# Altium schematic sheet lv_power_5V_cv.SchDoc(lv_power_5V_cv)
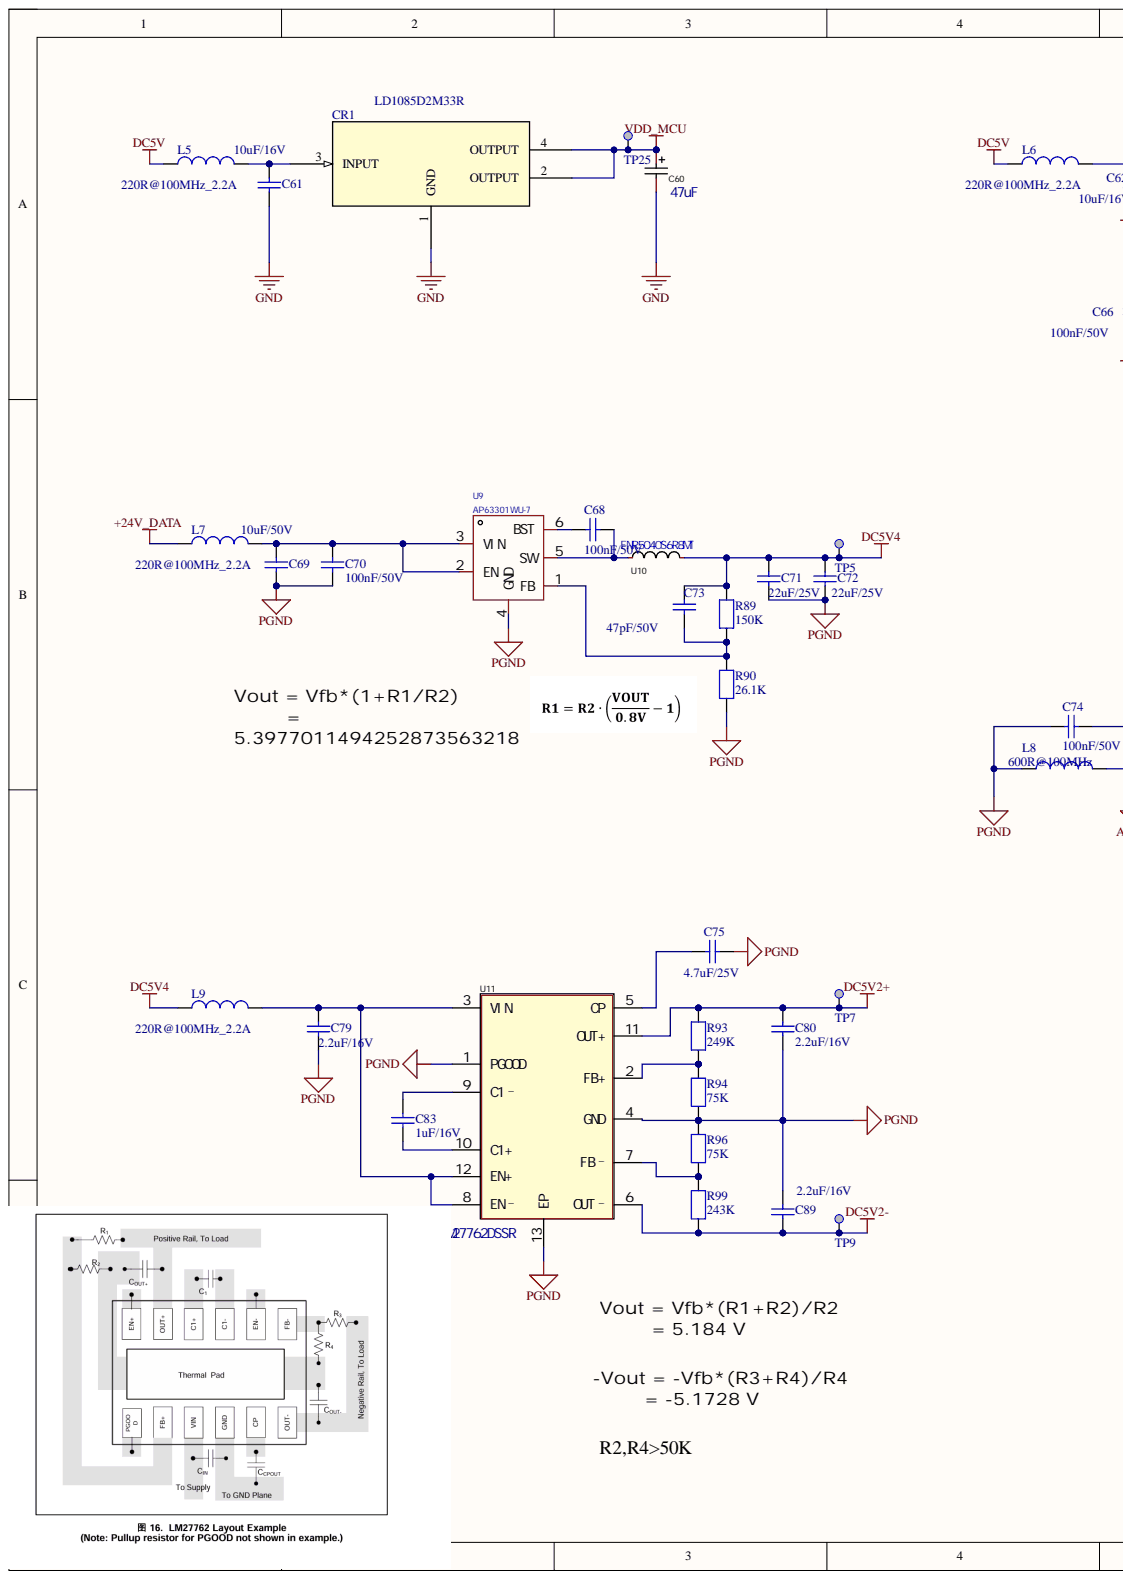
[diagram p0: lv_power_5V_cv.SchDoc, left]
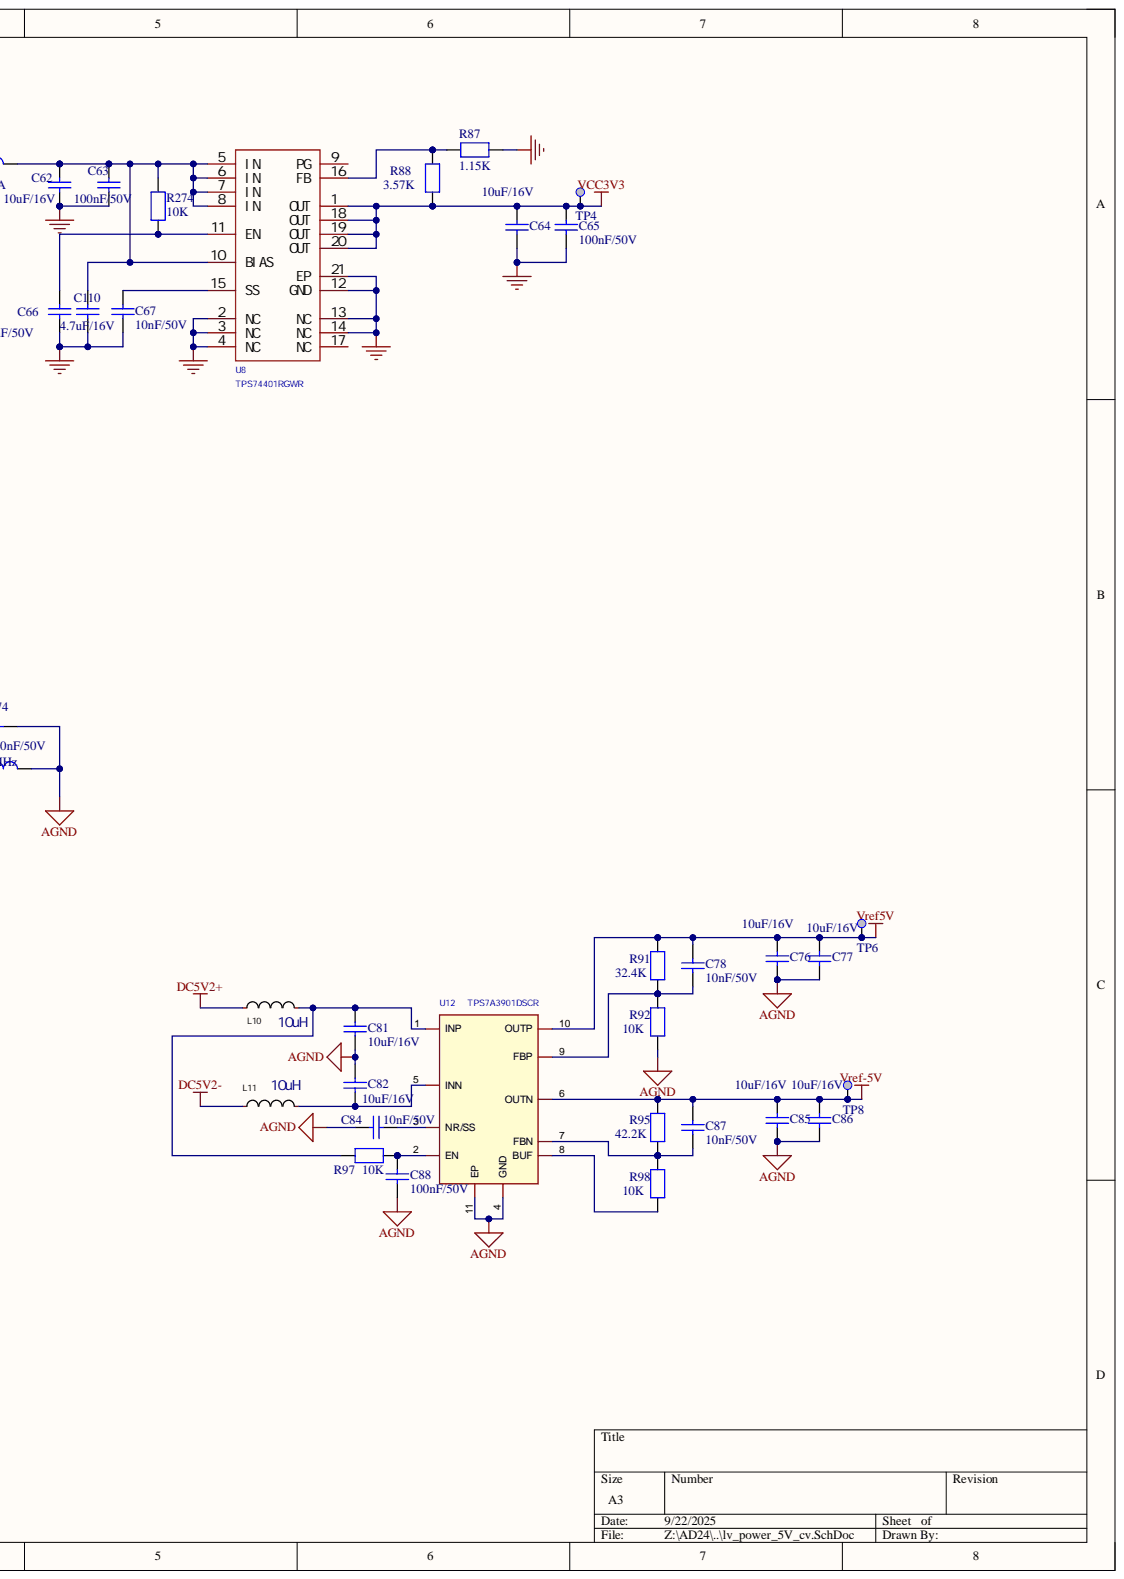
[diagram p1: lv_power_5V_cv.SchDoc, right]

## Parts
C60  47uF  [CAP-SMD_L6.0-W3.2-R-RD]
C61  10uF/16V  [C0805]
C62  10uF/16V  [C0805]
C63  100nF/50V  [C0402]
C64  10uF/16V  [C0805]
C65  100nF/50V  [C0402]
C66  100nF/50V  [C0402]
C67  10nF/50V  [C0402]
C68  100nF/50V  [C0402]
C69  10uF/50V  [C1206]
C70  100nF/50V  [C0402]
C71  22uF/25V  [C0805]
C72  22uF/25V  [C0805]
C73  47pF/50V  [C0402]
C74  100nF/50V  [C0402]
C75  4.7uF/25V  [C0805]
C76  10uF/16V  [C0805]
C77  10uF/16V  [C0805]
C78  10nF/50V  [C0402]
C79  2.2uF/16V  [C0603]
C80  2.2uF/16V  [C0603]
C81  10uF/16V  [C1206]
C82  10uF/16V  [C1206]
C83  1uF/16V  [C0603]
C84  10nF/50V  [C0402]
C85  10uF/16V  [C0805]
C86  10uF/16V  [C0805]
C87  10nF/50V  [C0402]
C88  100nF/50V  [C0402]
C89  2.2uF/16V  [C0603]
C110  4.7uF/16V  [C0603]
CR1  LD1085D2M33R  [D2PAKA_STM-L]
L5  220R@100MHz_2.2A  [L0603]
L6  220R@100MHz_2.2A  [L0603]
L7  220R@100MHz_2.2A  [L0603]
L8  600R@100MHz  [L0603]
L9  220R@100MHz_2.2A  [L0603]
L10  10uH  [R0805]
L11  10uH  [R0805]
R87  1.15K  [R0402]
R88  3.57K  [R0402]
R89  150K  [R0402]
R90  26.1K  [R0402]
R91  32.4K  [R0603]
R92  10K  [R0603]
R93  249K  [R0402]
R94  75K  [R0402]
R95  42.2K  [R0603]
R96  75K  [R0402]
R97  10K  [R0603]
R98  10K  [R0603]
R99  243K  [R0402]
R274  10K  [R0402]
TP4  Test Point  [TP_SMD_1MM]  (value not drawn)  (no BOM)
TP5  Test Point  [TP_SMD_1MM]  (value not drawn)  (no BOM)
TP6  Test Point  [TP_SMD_1MM]  (value not drawn)  (no BOM)
TP7  Test Point  [TP_SMD_1MM]  (value not drawn)  (no BOM)
TP8  Test Point  [TP_SMD_1MM]  (value not drawn)  (no BOM)
TP9  Test Point  [TP_SMD_1MM]  (value not drawn)  (no BOM)
TP25  Test Point  [TP_SMD_1MM]  (value not drawn)  (no BOM)
U8  TPS74401RGWR  [VQFN-20_L5.0-W5.0-P0.65-TL-EP]
U9  AP63301WU-7  [TSOT-23-6_L2.9-W1.6-P0.95-LS2.8-BR]
U10  FNR5040S6R8MT  [IND-SMD_L5.0-W5.0-LQH5BPN1R5NT0L]
U11  LM27762DSSR  [WSON-12_L3.0-W2.0-P0.50-BL-EP]
U12  TPS7A3901DSCR  [WSON-10_L3.0-W3.0-P0.50-BL-EP]

## Nets
+24V_DATA: L7.2
AGND: C74.2 C76.1 C77.1 C81.2 C82.2 C84.1 C85.1 C86.1 C88.2 L8.2 R92.1 U12.4(GND) U12.11(EP)
DC5V: L5.2 L6.2
DC5V2+: C80.1 L10.1 R93.1 TP7.1 U11.11(OUT+)
DC5V2-: C89.2 L11.1 R99.1 TP9.1 U11.6(OUT–)
DC5V4: C71.2 C72.2 C73.2 L9.2 R89.1 TP5.1 U10.2
GND: C60.2 C61.1 C62.1 C63.2 C64.1 C65.2 C66.2 C67.2 C110.1 CR1.1(GND) R87.1 U8.2(NC) U8.3(NC) U8.4(NC) U8.12(GND) U8.13(NC) U8.14(NC) U8.21(EP)
PGND: C69.1 C70.2 C71.1 C72.1 C74.1 C75.2 C79.2 C80.2 C89.1 L8.1 R90.2 R94.2 R96.2 U9.4(GND) U11.1(PGOOD) U11.4(GND) U11.13(EP)
VCC3V3: C64.2 C65.1 R88.1 TP4.1 U8.1(OUT) U8.18(OUT) U8.19(OUT) U8.20(OUT)
VDD_MCU: C60.1 CR1.2(OUTPUT) CR1.4(OUTPUT) TP25.1
Vref5V: C76.2 C77.2 C78.1 R91.1 TP6.1 U12.10(OUTP)
Vref-5V: C85.2 C86.2 C87.1 R95.1 TP8.1 U12.6(OUTN)
NetC61_2: C61.2 CR1.3(INPUT) L5.1
NetC62_2: C62.2 C63.1 C110.2 L6.1 R274.1 U8.5(IN) U8.6(IN) U8.7(IN) U8.8(IN) U8.10(BIAS)
NetC66_1: C66.1 R274.2 U8.11(EN)
NetC67_1: C67.1 U8.15(SS)
NetC68_1: C68.1 U9.6(BST)
NetC68_2: C68.2 U9.5(SW) U10.1
NetC69_2: C69.2 C70.1 L7.1 U9.2(EN) U9.3(VIN)
NetC73_1: C73.1 R89.2 R90.1 U9.1(FB)
NetC75_1: C75.1 U11.5(CP)
NetC78_2: C78.2 R91.2 R92.2 U12.9(FBP)
NetC79_1: C79.1 L9.1 U11.3(VIN) U11.8(EN–) U11.12(EN+)
NetC81_1: C81.1 L10.2 R97.1 U12.1(INP)
NetC82_1: C82.1 L11.2 U12.5(INN)
NetC83_1: C83.1 U11.9(C1–)
NetC83_2: C83.2 U11.10(C1+)
NetC84_2: C84.2 U12.3(NR/SS)
NetC87_2: C87.2 R95.2 R98.2 U12.7(FBN)
NetC88_1: C88.1 R97.2 U12.2(EN)
NetR87_2: R87.2 R88.2 U8.16(FB)
NetR93_2: R93.2 R94.1 U11.2(FB+)
NetR96_1: R96.1 R99.2 U11.7(FB–)
NetR98_1: R98.1 U12.8(BUF)

Not connected: U8 9(PG) 17(NC)

## Library data of the parts
C60 = TAJD107M020RNJ: 16V，±10%，500mΩ@100kHz; Manufacturer: Kyocera AVX; Manufacturer Part: TAJC476K016RNJ; Component Kind: Standard; Library Name: HiPin_Library_AD.IntLib
C61 C62 C64 C76 C77 C85 C86 = Capacitor_3: 16V, X7R, 10%; Manufacturer: YAGEO(国巨); Manufacturer_Part_Number: CC0805KKX7R7BB106; Component Kind: Standard; Library Name: HiPin_Library_AD.IntLib
C63 C65 C66 C68 C70 C74 C88 = Capacitor_2: 50V, X7R , 10%; Manufacturer: muRata; Manufacturer_Part_Number: GRM155R71H104KE14D; Component Kind: Standard; ComponentLink1Description: Datasheet; ComponentLink1URL: http://tunmcdsvn.tun.st.com/Component_Datasheet_Library/Trunk/Capacitors/Yageo_Multilayer_capacitor_X5R_series_100pF_to_100uF; Library Name: HiPin_Library_AD.IntLib
C67 C78 C84 C87 = Capacitor_2: 50V, X7R , 5%; Manufacturer: YAGEO; Manufacturer_Part_Number: CC0402JRX7R9BB103; Component Kind: Standard; ComponentLink1Description: Datasheet; ComponentLink1URL: http://tunmcdsvn.tun.st.com/Component_Datasheet_Library/Trunk/Capacitors/Yageo_Multilayer_capacitor_X5R_series_100pF_to_100uF; Library Name: HiPin_Library_AD.IntLib
C69 = Capacitor_3: 50V, X5R, 10%; Manufacturer: YAGEO(国巨); Manufacturer_Part_Number: CC1206KKX5R9BB106; Component Kind: Standard; Library Name: HiPin_Library_AD.IntLib
C71 C72 = Capacitor_2: 25V, X5R, 10%; Internal_Part_Number: MCD-00014; Manufacturer: muRata; Manufacturer_Part_Number: GRM21BR61E226ME44L; Component Kind: Standard; ComponentLink1Description: Datasheet; ComponentLink1URL: http://tunmcdsvn.tun.st.com/Component_Datasheet_Library/Trunk/Capacitors/Yageo_Multilayer_capacitor_X5R_series_100pF_to_100uF; Library Name: HiPin_Library_AD.IntLib
C73 = Capacitor: 50V, 10%, C0G; Internal_Part_Number: MCD-00003; Manufacturer: muRata; Manufacturer_Part_Number: GCM1555C1H560FA16D; Value: 47pF; Component Kind: Standard; ComponentLink1Description: Datasheet; ComponentLink1URL: http://tunmcdsvn.tun.st.com/Component_Datasheet_Library/Trunk/Capacitors/Yageo_Capacitor_NP0_16V-to-50V; Library Name: HiPin_Library_AD.IntLib; Package: C0402
C75 = Capacitor_2: 25V, X7R , 10%; Manufacturer: YAGEO; Manufacturer_Part_Number: CC0805KKX7R8BB475; Component Kind: Standard; ComponentLink1Description: Datasheet; ComponentLink1URL: http://tunmcdsvn.tun.st.com/Component_Datasheet_Library/Trunk/Capacitors/Yageo_Multilayer_capacitor_X5R_series_100pF_to_100uF; Library Name: HiPin_Library_AD.IntLib
C79 C80 C89 = Capacitor_2: 16V, X7R , 10%; Manufacturer: YAGEO; Manufacturer_Part_Number: CC0603KRX7R7BB225; Component Kind: Standard; ComponentLink1Description: Datasheet; ComponentLink1URL: http://tunmcdsvn.tun.st.com/Component_Datasheet_Library/Trunk/Capacitors/Yageo_Multilayer_capacitor_X5R_series_100pF_to_100uF; Library Name: HiPin_Library_AD.IntLib
C81 C82 = MCD-00097: 16V, X7R, 10%; Alternate_Manufacturer: Murata; Alternate_Manufacturer_Part_Number: GRM188R61C475MAAJ; Manufacturer: YAGEO(国巨); Manufacturer_Part_Number: CC0805KKX7R7BB106; Database Table Name: MCD_PARTS$; ECCN_EU: NEC; ECCN_US: EAR99; Provided_By: SUBCO; Component Kind: Standard; ComponentLink1Description: Datasheet; ComponentLink1URL: http://tunmcdsvn.tun.st.com/Component_Datasheet_Library/Trunk/Capacitors/Murata_Capacitor_GR_series; Library Name: MCD_PARTS_database.DbLib; Package: C0603; Status: Released
C83 = Capacitor_2: 16V, X7R , 10%; Manufacturer: muRata; Manufacturer_Part_Number: GRM188R71C105KE15D; Component Kind: Standard; ComponentLink1Description: Datasheet; ComponentLink1URL: http://tunmcdsvn.tun.st.com/Component_Datasheet_Library/Trunk/Capacitors/Yageo_Multilayer_capacitor_X5R_series_100pF_to_100uF; Library Name: HiPin_Library_AD.IntLib
C110 = MCD-01121: 16V, X5R, 20%,; Manufacturer: MURATA; Manufacturer_Part_Number: GRM188R61C475KE11D; Database Table Name: MCD_PARTS$; Component Kind: Standard; ComponentLink1Description: Datasheet; ComponentLink1URL: http://tunmcdsvn.tun.st.com/Component_Datasheet_Library/Trunk/Capacitors/Murata_Capacitor_GR_series; Library Name: MCD_PARTS_database.DbLib
CR1 = LD1085D2M33R: 3 A low drop positive voltage regulator: adjustable and fixed; Manufacturer_Name: STMicroelectronics; Component Kind: Standard; Datasheet: https://www.st.com/resource/en/datasheet/ld1085.pdf; Library Name: HiPin_Library_AD.IntLib; Manufacturer_Part_Number: LD1085D2M33R; RefDes: RefDes; Type: Type; Copyright: Copyright (C) 2025 Ultra Librarian. All rights reserved.
L5-L7 L9 = MCD-00047: SMD Chip ferrite bead, 220R@100MHz +/-25%,2.2A, 50mohm; Manufacturer: Sunlord; Manufacturer_Part_Number: UPZ1608U221-2R2TF; Database Table Name: MCD_PARTS$; Component Kind: Standard; ComponentLink1Description: Datasheet; ComponentLink1URL: http://tunmcdsvn.tun.st.com/Component_Datasheet_Library/Trunk/Inductors/TaiTech_Bead_FCM1608_series; Internal_Part_Number: MCD-00047; Library Name: MCD_PARTS_database.DbLib
L8 = MCD-00047: +/-25%, 350mA, 500mohm DC; Manufacturer: TAI-TECH; Manufacturer_Part_Number: FCM1608KF-601T03; Database Table Name: MCD_PARTS$; Component Kind: Standard; ComponentLink1Description: Datasheet; ComponentLink1URL: http://tunmcdsvn.tun.st.com/Component_Datasheet_Library/Trunk/Inductors/TaiTech_Bead_FCM1608_series; Library Name: MCD_PARTS_database.DbLib
L10 L11 = WPN252012H1R0MT: ±20% ,0.36A, 550mΩ; Manufacturer Part: SLM2012100MIT; Component Kind: Standard; Library Name: HiPin_Library_AD.IntLib
R87-R89 R91 R93-R96 R99 = RES: 1%; Value: 10K; Component Kind: Standard; Library Name: HiPin_Library_AD.IntLib
R90 R92 R97 R98 R274 = RES: 1%; Component Kind: Standard; Library Name: HiPin_Library_AD.IntLib
  R92 R97 R98 R274: Value: 10K
TP4-TP9 TP25 = MCD-00074: Test Point 1mm; Part_Type: Mechanical; Database Table Name: MCD_PARTS$; ComponentLink1Description: Datasheet; ComponentLink1URL: http://na; ECCN_EU: n/a; ECCN_US: n/a; Internal_Part_Number: MCD-00074; Library Name: MCD_PARTS_database.DbLib; Manufacturer: n/a; Manufacturer_Part_Number: n/a; Provided_By: n/a; Status: Released
U8 = TPS74401RGWR: Manufacturer: TI(德州仪器); Add into BOM: yes; Dropout Voltage: 240mV@(3A); Maximum Input Voltage: 5.5V; Number of Outputs: 1; Output Current: 3A; Output Polarity: 正极; Output Type: 可调; Output Voltage: 800mV~3.6V; Power Supply Rejection Ratio (PSRR): 73dB; Component Kind: Standard; Convert to PCB: yes; Datasheet: https://www.ti.com/cn/lit/gpn/tps74401; Device: TPS74401RGWR; Feature: 防静电保护；浪涌保护；热保护(TSD)；欠压闭锁；过流保护(OCP)；带使能; JLCPCB Part Class: Extended Part; LCSC Part Name: 3A、低输入电压 (0.8V)、低噪声、高 PSRR、可调节超低压降稳压器; Library Name: HiPin_Library_AD.IntLib; Manufacturer Part: TPS74401RGWR; PCB3D: VQFN-20_L5.0-W5.0-H1.0-P0.65; Supplier: LCSC; Supplier Footprint: VQFN-20-EP(5x5); Supplier Part: C138728; Symbol: TPS74401RGWR
U9 = AP63301WU-7: Manufacturer: DIODES(美台); Add into BOM: yes; Function: 降压型; Input Voltage: 3.8V~32V; Number of Outputs: 1; Output Current: 3A; Output Type: 固定; Output Voltage: 800mV~31V; Quiescent Current (Iq): 22uA; Switch tube (built-in/external): 内置; Switching Frequency: 500kHz; Synchronous Rectification: 是; Topology: 降压式; Component Kind: Standard; Convert to PCB: yes; Datasheet: https://atta.szlcsc.com/upload/public/pdf/source/20221028/E7CD33B2C218A57E79B7F5DC455D7FF6.pdf; Device: AP63301WU-7; JLCPCB Part Class: Extended Part; LCSC Part Name: 3.8V至32V输入，3A低静态电流同步降压转换器，具有增强的电磁干扰抑制功能; Library Name: HiPin_Library_AD.IntLib; Manufacturer Part: AP63301WU-7; Operating Temperature: -40℃~+125℃; PCB3D: TSOT-23-6_L2.9-W1.6-H1.1-LS2.8-P0.95; Supplier: LCSC; Supplier Footprint: TSOT-23-6; Supplier Part: C2158003; Symbol: AP63301WU-7
U10 = LQH5BPH2R2NT0L: 电感值: 6.8uH  精度: ±20%  额定电流: 2.8A  饱和电流(Isat): 3.4A; Manufacturer: cjiang(长江微电); Component Kind: Standard; Library Name: HiPin_Library_AD.IntLib; Manufacturer Part: FNR5040S6R8MT; PCB3D: IND-SMD_L5.0-W5.0-LQH5BPN1R5NT0L
U11 = LM27762DSSR: 电荷泵  工作电压2.7V~5.5V,静态电流390uA,输出电阻,2.5Ω,开关频率2MHz; Manufacturer: TI(��������); Add into BOM: yes; Function: ��ɱ�; Number of Outputs: 2; Output Current (Max): 250mA; Output Voltage: -5V~-1.5V��1.5V~5V; Switching Frequency: 2.3MHz; Synchronous Rectification: ��; Component Kind: Standard; Convert to PCB: yes; Datasheet: https://www.ti.com/cn/lit/gpn/lm27762; Device: LM27762DSSR; Input Voltage: 2.7V~5.5V; JLCPCB Part Class: Extended Part; LCSC Part Name: LM27762DSSR; Library Name: HiPin_Library_AD.IntLib; Manufacturer Part: LM27762DSSR; Supplier: LCSC; Supplier Footprint: DFN-12(2x3); Supplier Part: C473398; Symbol: LM27762DSSR; Topology: -
U12 = TPS7A3901DSCR: Manufacturer: TI(德州仪器); Add into BOM: yes; Maximum Input Voltage: 33V; Number of Outputs: 2; Output Current: 150mA; Output Polarity: 正极和负极; Output Type: 可调; Output Voltage: 1.2V~30V；0V~30V; Power Supply Rejection Ratio (PSRR): 56dB@(100KHz); Component Kind: Standard; Convert to PCB: yes; Datasheet: https://www.ti.com/cn/lit/gpn/tps7a39; Device: TPS7A3901DSCR; JLCPCB Part Class: Extended Part; LCSC Part Name: 150mA、33V、低噪声、高 PSRR、双通道、正负电压范围、低压降稳压器; Library Name: HiPin_Library_AD.IntLib; Manufacturer Part: TPS7A3901DSCR; Operating Temperature: -40℃~+125℃@(Tj); PCB3D: WSON-10_L3.0-W3.0-H1.0-P0.50; Supplier: LCSC; Supplier Footprint: WSON-10-EP(3x3); Supplier Part: C2685819; Symbol: TPS7A3901DSCR
